# Altium schematic sheet Sheet2.SchDoc
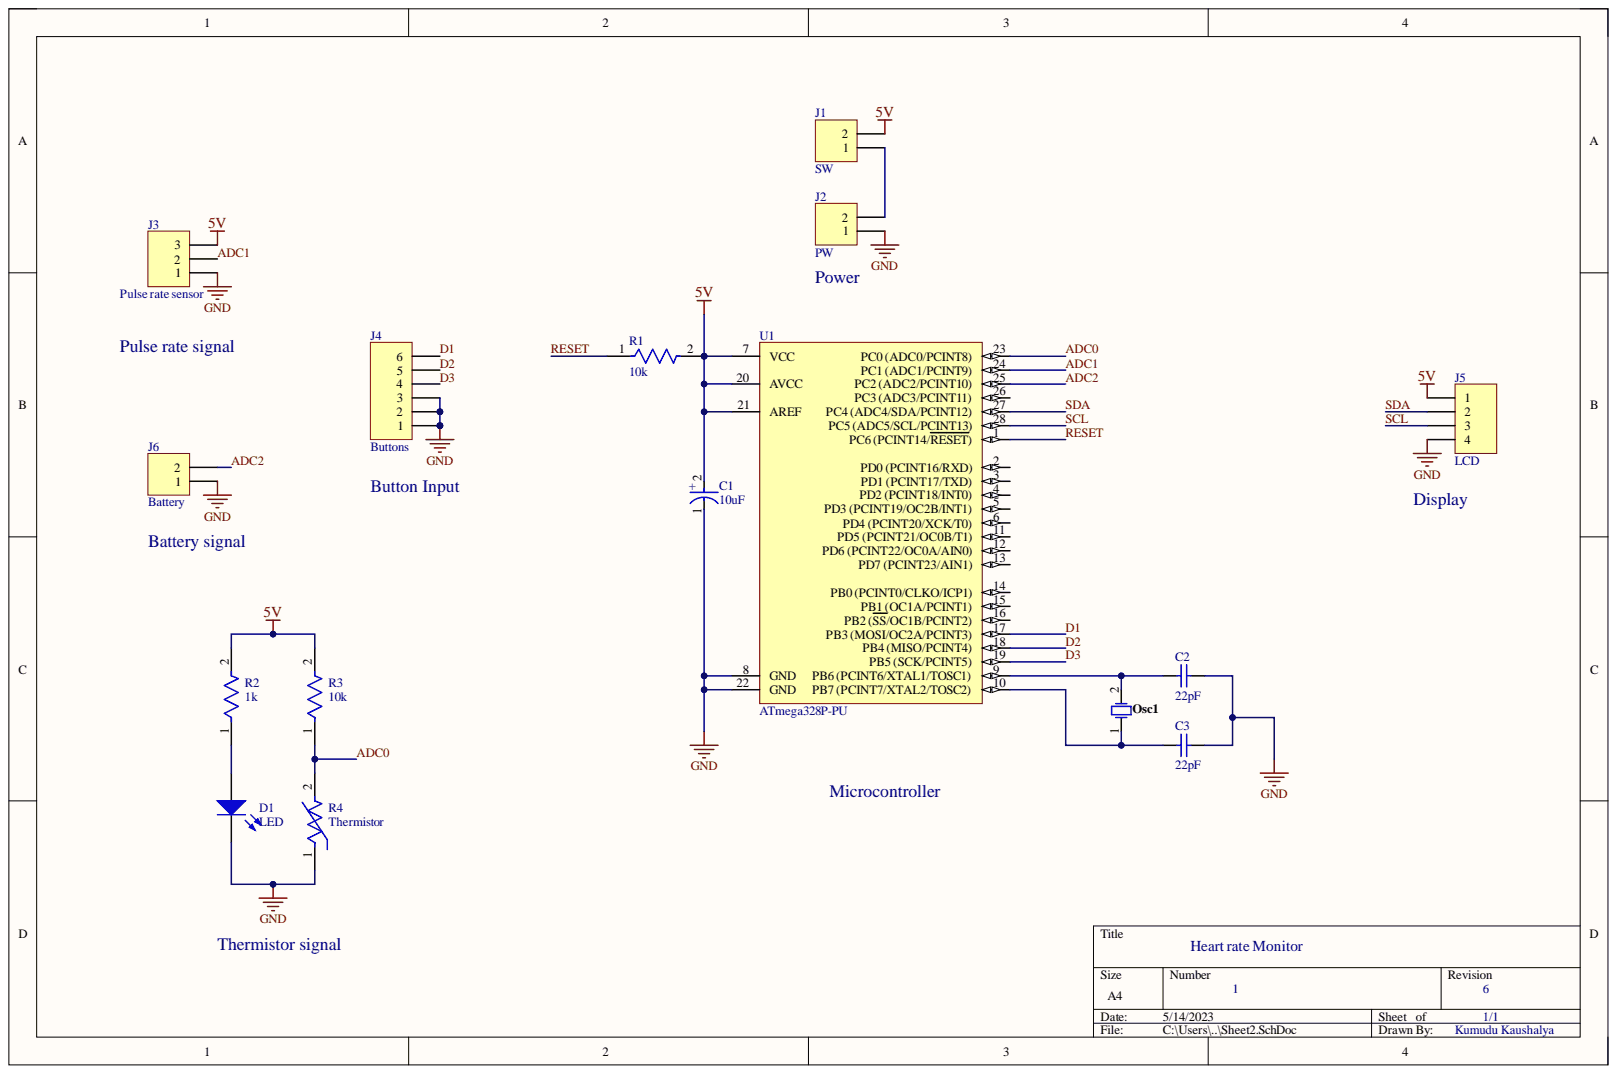
[diagram p0: Sheet2.SchDoc]

## Parts
C1  10uF  [FP-RAD-TH-D_5_0_5-L_11_1-MFG]
C2  22pF  [KEMT-C315-THT-2]
C3  22pF  [KEMT-C315-THT-2]
D1  LED  [LED-1]
J1  SW  [HDR1X2]
J2  PW  [HDR1X2]
J3  Pulse rate sensor  [HDR1X3]
J4  Buttons  [HDR1X6]
J5  LCD  [HDR1X4]
J6  Battery  [HDR1X2]
Osc1  Osc1  [FOX-FOXSLF160-20]
R1  10k  [FP-MBB0207-MFG]
R2  1k  [FP-MRS25-MFG]
R3  10k  [FP-MBB0207-MFG]
R4  Thermistor  [FP-NTCLE100E3104JB0-MFG]
U1  ATmega328P-PU  [28P3]

## Nets
5V: C1.2 J1.2 J3.3 J5.1 R1.2 R2.2 R3.2 U1.7(VCC) U1.20(AVCC) U1.21(AREF)
GND: C1.1 C2.2 C3.2 D1.2 J2.1 J3.1 J4.1 J4.2 J4.3 J5.4 J6.1 R4.1 U1.8(GND) U1.22(GND)
ADC0: R3.1 R4.2 U1.23
ADC1: J3.2 U1.24(PC1_(ADC1/PCINT9))
ADC2: J6.2 U1.25
D1: J4.6 U1.17(PB3_(MOSI/OC2A/PCINT3))
D2: J4.5 U1.18(PB4_(MISO/PCINT4))
D3: J4.4 U1.19(PB5_(SCK/PCINT5))
RESET: R1.1 U1.1(PC6_(PCINT14/RESET))
SCL: J5.3 U1.28(PC5_(ADC5/SCL/PCINT13))
SDA: J5.2 U1.27
NetC2_1: C2.1 Osc1.2 U1.9
NetC3_1: C3.1 Osc1.1 U1.10
NetD1_1: D1.1 R2.1
NetJ1_1: J1.1 J2.2

Not connected: U1 2(PD0_(PCINT16/RXD)) 3(PD1_(PCINT17/TXD)) 4(PD2_(PCINT18/INT0)) 5(PD3_(PCINT19/OC2B/INT1)) 6(PD4_(PCINT20/XCK/T0)) 11(PD5_(PCINT21/OC0B/T1)) 12(PD6_(PCINT22/OC0A/AIN0)) 13(PD7_(PCINT23/AIN1)) 14(PB0_(PCINT0/CLKO/ICP1)) 15(PB1_(OC1A/PCINT1)) 16(PB2_(SS/OC1B/PCINT2)) 26(PC3_(ADC3/PCINT11))

## Library data of the parts
C1 = CMP-05427-000869-1: Aluminum Electrolytic Capacitors (Radial Lead Type) 10uF ±20% 100V; Dielectric Material: Aluminium; Dissipation Factor: 0.1%; Lead Diameter: 0.5mm; Lead/Base Style: Straight; Leakage Current: 3uA; Life (Hours): 2000Hour; Polarity: Polar; Ripple Current: 70mA; Capacitance: 10uF; Case/Package: Radial; Component Kind: Standard; Construction: RADIAL; Contact Plating: Tin; Diameter: 5mm; Height: 11mm; Height - Seated (Max): 0.472inch; Lead Free: Lead Free; Lead Pitch: 2mm; Length: 11mm; Library Name: Altium Content Vault; Max Operating Temperature: 85°C; Min Operating Temperature: -40°C; Mount: Through Hole; Number of Pins: 2; Package Quantity: 200; Packaging: Bulk; Radiation Hardening: No; REACH SVHC: No SVHC; Ripple Current (AC): 70mA; RoHS Compliant: Yes; Series: M; Termination: Radial; Tolerance: 20%; Voltage: 100V; Voltage Rating: 100V; Voltage Rating (DC): 100V; Width: 5mm
C2 C3 = CMP-1664-00008-1: Capacitor, Ceramic, 22 pF, +/-10 %, 100 V, -55 to 125 degC, 2-Pin THD, RoHS, Bulk; Case\Package: TO-220; Max Input Voltage: 40 V; Max Ouput Current: 1.5 A; Min Input Voltage: 4.2 V; Output Voltage: 1.2 V; Outputs: 1; Component Kind: Standard; ComponentLink1Description: Manufacturer URL; ComponentLink1URL: http://www.kemet.com/; ComponentLink2Description: Datasheet; ComponentLink2URL: http://capacitoredge.kemet.com/capedge2/DataSheet/Datasheet-C315C220K1G5TA.pdf?pn=C315C220K1G5TA{BULK}; DatasheetVersion: Aug-16; Height: 9.28 mm; Length: 10.28 mm; Library Name: Altium Content Vault; Max Operating Temperature: 125 degC; Min Operating Temperature: 0 degC; Mount: Through Hole; Output Current: 1.5 A; Package Quantity: 50; PackageDescription: 2-Pin Through Hole Device, Body 3.81 x 2.54 mm; PackageReference: C315-THT-2; Packaging: Rail/Tube; Pins: 3; Radiation Hardening: No; REACH SVHC: No SVHC; RoHSCompliant: true; Weight: 0.08113 oz; Width: 4.82 mm
D1 = LED1: Typical RED GaAs LED; Component Kind: Standard; LatestRevisionDate: 08-Jul-2005; LatestRevisionNote: Mech Layer 1 removed.; Library Name: Miscellaneous Devices.IntLib; PackageDescription: LED; 2 Leads; PackageReference: LED-1; Published: 8-Jun-2000; Simulation: LED1; Publisher: Altium Limited
J1 J2 J6 = Header 2: Header, 2-Pin; Component Kind: Standard; LatestRevisionDate: 17-Jul-2002; LatestRevisionNote: Re-released for DXP Platform.; Library Name: Miscellaneous Connectors.IntLib; Signal Integrity: Connector; Publisher: Altium Limited
J3 = Header 3: Header, 3-Pin; Component Kind: Standard; LatestRevisionDate: 17-Jul-2002; LatestRevisionNote: Re-released for DXP Platform.; Library Name: Miscellaneous Connectors.IntLib; Signal Integrity: Connector; Publisher: Altium Limited
J4 = Header 6: Header, 6-Pin; Component Kind: Standard; LatestRevisionDate: 17-Jul-2002; LatestRevisionNote: Re-released for DXP Platform.; Library Name: Miscellaneous Connectors.IntLib; Signal Integrity: Connector; Publisher: Altium Limited
J5 = Header 4: Header, 4-Pin; Component Kind: Standard; LatestRevisionDate: 17-Jul-2002; LatestRevisionNote: Re-released for DXP Platform.; Library Name: Miscellaneous Connectors.IntLib; Signal Integrity: Connector; Publisher: Altium Limited
Osc1 = CMP-1749-00003-1: Resistance Weld Thru-Hole Crystal, 16 MHz, -20 to 70 degC, 2-Pin THD, RoHS, Bulk; Case\Package: Axial; Power Rating: 250 mW; Resistance: 221 kohm; Tolerance: 1%; Voltage Rating: 250 V; Component Kind: Standard; ComponentLink1Description: Manufacturer URL; ComponentLink1URL: http://www.foxonline.com/; ComponentLink2Description: Datasheet; ComponentLink2URL: http://www.foxonline.com/pdfs/hc49slf.pdf; DatasheetVersion: Mar-15; Length: 0.248 inch; Library Name: Altium Content Vault; Max Operating Temperature: 155 degC; Min Operating Temperature: -55 degC; Mount: Through Hole; Package Quantity: 200; PackageDescription: 2-Pin Through Hole Device, Body 11.35 x 4.65 mm; PackageReference: FOXSLF160-20; Packaging: Bulk; RoHSCompliant: true
R1 R3 = CMP-02408-000035-1: RES 10K OHM 0.6W 1% AXIAL; Max Power Dissipation: 600mW; Resistance: 10kR; Temperature Coefficient: 50ppm/°C; Voltage Rating: 300V; Case/Package: Conformal; Component Kind: Standard; Construction: Cylindrical; Contact Plating: Tin; Diameter: 2.5mm; Features: Automotive AEC-Q200; Lead Free: Lead Free; Length: 6.5mm; Library Name: Altium Content Vault; Max Operating Temperature: 155°C; Military Standard: Not; Min Operating Temperature: -55°C; Number of Terminations: 2; Packaging: Tape and Reel; Power Rating: 600mW; Radiation Hardening: No; Resistance Tolerance: 1%; Resistor Type: Metal Film; RoHS Compliant: Yes; Series: MBB 0207 - Professional; Technology: THIN FILM; Termination: Axial; Tolerance: 1%; Voltage Rating (DC): 300V
R2 = CMP-02408-000051-1: RES 1K OHM 0.6W 1% AXIAL; Lead Diameter: 0.6mm; Max Power Dissipation: 600mW; Resistance: 1kR; Temperature Coefficient: 50ppm/°C; Voltage Rating: 350V; Case/Package: Axial; Component Kind: Standard; Construction: Cylindrical; Contact Plating: Tin; Diameter: 2.5mm; Lead Free: Lead Free; Length: 6.5mm; Library Name: Altium Content Vault; Max Operating Temperature: 155°C; Military Standard: Not; Min Operating Temperature: -55°C; Mount: Through Hole; Number of Pins: 2; Number of Terminations: 2; Package Quantity: 5000; Packaging: Tape and Reel; Power Rating: 600mW; Radiation Hardening: No; Resistance Tolerance: 1%; Resistor Type: Metal Film; RoHS Compliant: Yes; Series: MRS25; Technology: THIN FILM; Termination: Axial; Tolerance: 1%; Voltage Rating (DC): 350V; Weight: 0.00776oz; Width: 2.5mm
R4 = CMP-02404-000021-1: NTC THERMISTOR 100K OHM 5% BEAD; Accuracy: 1.5%; Lead Diameter: 0.6mm; Lead Length: 17mm; Max Power Dissipation: 500mW; Resistance: 100kR; Case/Package: Radial; Component Kind: Standard; Depth: 3mm; Height: 9mm; Lead Free: Lead Free; Lead Pitch: 2.54mm; Length: 3.3mm; Library Name: Altium Content Vault; Max Operating Temperature: 125°C; Min Operating Temperature: -40°C; Mount: Through Hole; Number of Pins: 2; Package Quantity: 500; Packaging: Bulk; Radiation Hardening: No; Resistance Tolerance: 5%; RoHS Compliant: Yes; Series: 2322; Termination: Radial; Tolerance: 5%
U1 = CMP-0095-00013-2: 8-bit AVR Microcontroller, 32KB Flash, 1KB EEPROM, 2KB SRAM, 28-pin PDIP, Industrial Grade (-40°C to 85°C); Component Kind: Standard; ComponentLink1Description: Manufacturer URL; ComponentLink1URL: http://www.atmel.com/; ComponentLink2Description: ATmega48A/48PA/88A/88PA/168A/168PA/328/328P Datasheet; ComponentLink2URL: http://www.atmel.com/dyn/resources/prod_documents/8271.pdf; ComponentLink3Description: ATmega48A/48PA/88A/88PA/168A/168PA/328/328P Summary; ComponentLink3URL: http://www.atmel.com/dyn/resources/prod_documents/8271S.pdf; DatasheetVersion: revD, May-2011; Library Name: Altium Content Vault; PackageDescription: 28-lead (0.300"/7.62mm Wide) Plastic Dual Inline Package (PDIP); PackageReference: 28P3; PackageVersion: revB, Sep-2001; RevisionNote: DatasheetVersion updated. URLs added.
